# Altium schematic sheet motor_driver.SchDoc
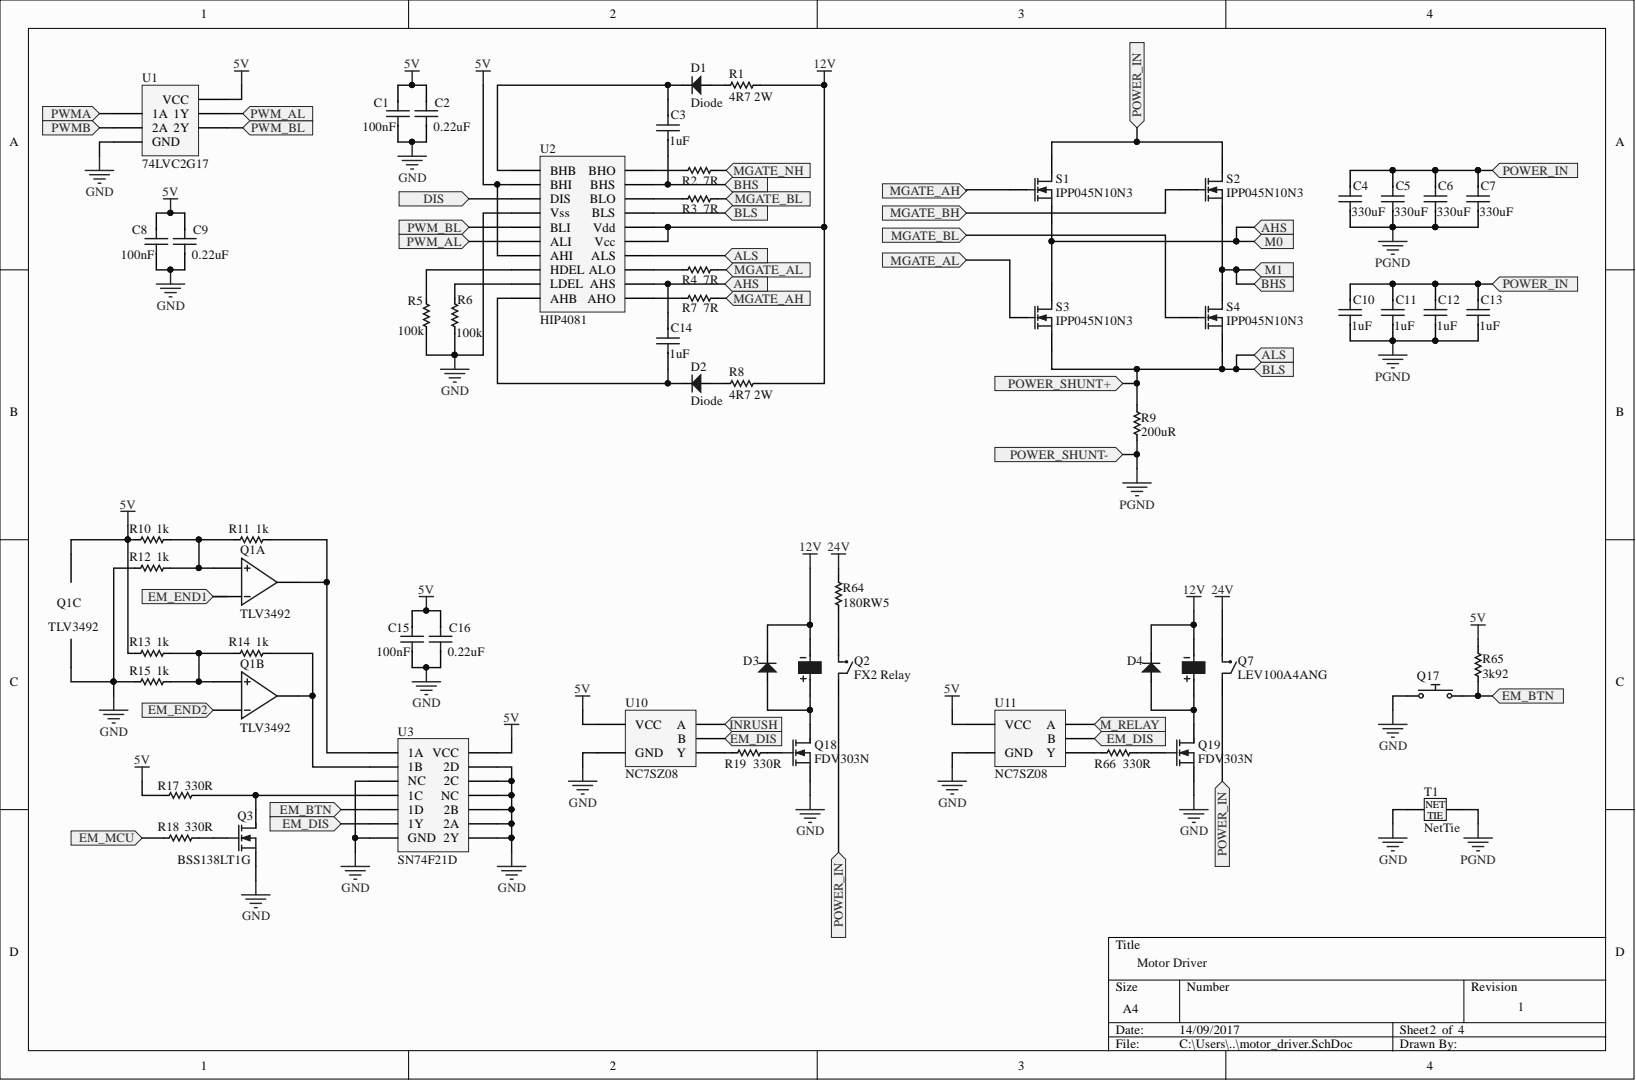
[diagram p0: motor_driver.SchDoc]

## Parts
C1  value 100nF  [0805]  (hidden comment: Capacitor)
C2  value 0.22uF  [0805]  (hidden comment: Capacitor)
C3  value 1uF  [0805]  (hidden comment: Capacitor)
C4  value 330uF  [CAP-1621]  (hidden comment: Capacitor)
C5  value 330uF  [CAP-1621]  (hidden comment: Capacitor)
C6  value 330uF  [CAP-1621]  (hidden comment: Capacitor)
C7  value 330uF  [CAP-1621]  (hidden comment: Capacitor)
C8  value 100nF  [0805]  (hidden comment: Capacitor)
C9  value 0.22uF  [0805]  (hidden comment: Capacitor)
C10  value 1uF  [0805]  (hidden comment: Capacitor)
C11  value 1uF  [0805]  (hidden comment: Capacitor)
C12  value 1uF  [0805]  (hidden comment: Capacitor)
C13  value 1uF  [0805]  (hidden comment: Capacitor)
C14  value 1uF  [0805]  (hidden comment: Capacitor)
C15  value 100nF  [0805]  (hidden comment: Capacitor)
C16  value 0.22uF  [0805]  (hidden comment: Capacitor)
D1  Diode  [DO-214AC]
D2  Diode  [DO-214AC]
D3  Diode  [DO-214AC]  (value not drawn)
D4  Diode  [DO-214AC]  (value not drawn)
Q1  TLV3492  (parts A,B,C)
Q2  FX2 Relay  [G6BK]
Q3  BSS138LT1G  [SOT-23B]
Q7  LEV100A4ANG
Q17  Emergency Button  (value not drawn)
Q18  FDV303N  [SOT-23B]
Q19  FDV303N  [SOT-23B]
R1  value 4R7 2W  [RES-2512]  (hidden comment: Resistor)
R2  value 7R  [0805]  (hidden comment: Resistor)
R3  value 7R  [0805]  (hidden comment: Resistor)
R4  value 7R  [0805]  (hidden comment: Resistor)
R5  value 100k  [0805]  (hidden comment: Resistor)
R6  value 100k  [0805]  (hidden comment: Resistor)
R7  value 7R  [0805]  (hidden comment: Resistor)
R8  value 4R7 2W  [RES-2512]  (hidden comment: Resistor)
R9  value 200uR  [RES-3920]  (hidden comment: Resistor)
R10  value 1k  [0805]  (hidden comment: Resistor)
R11  value 1k  [0805]  (hidden comment: Resistor)
R12  value 1k  [0805]  (hidden comment: Resistor)
R13  value 1k  [0805]  (hidden comment: Resistor)
R14  value 1k  [0805]  (hidden comment: Resistor)
R15  value 1k  [0805]  (hidden comment: Resistor)
R17  value 330R  [0805]  (hidden comment: Resistor)
R18  value 330R  [0805]  (hidden comment: Resistor)
R19  value 330R  [0805]  (hidden comment: Resistor)
R64  value 180RW5  [RES-RWS5]  (hidden comment: Resistor)
R65  value 3k92  (hidden comment: Resistor)
R66  value 330R  [0805]  (hidden comment: Resistor)
S1  IPP045N10N3  [TO-220AB]
S2  IPP045N10N3  [TO-220AB]
S3  IPP045N10N3  [TO-220AB]
S4  IPP045N10N3  [TO-220AB]
T1  NetTie  [NetTie]
U1  74LVC2G17  [SOT-363]
U2  HIP4081  [SOIC-20 WB]
U3  SN74F21D  [SOIC-14]
U10  NC7SZ08
U11  NC7SZ08

## Ports
AHS, ALS, BHS, BLS, DIS, EM_BTN, EM_DIS, EM_END1, EM_END2, EM_MCU, INRUSH, M0, M1, M_RELAY, MGATE_AH, MGATE_AL, MGATE_BH, MGATE_BL, MGATE_NH, POWER_IN, POWER_SHUNT+, POWER_SHUNT-, PWM_AL, PWM_BL, PWMA, PWMB

## Nets
5V: C1.2 C2.2 C8.2 C9.2 C15.2 C16.2 Q1.8 R10.1 R13.1 R17.1 R65.0 U1.5(VCC) U2.2(BHI) U2.7(AHI) U3.14(VCC) U10.5(VCC) U11.5(VCC)
12V: D3.1 D4.1 Q2.1 Q7.1 R1.0 R8.0 U2.15(Vcc) U2.16(Vdd)
24V: Q7.4 R64.0
GND: C1.1 C2.1 C8.1 C9.1 C15.1 C16.1 Q1.4 Q3.2 Q17.1 Q18.2 Q19.2 R5.0 R6.0 R12.1 R15.1 T1.2 U1.2(GND) U2.4(Vss) U3.3(NC) U3.7(GND) U3.8(2Y) U3.9(2A) U3.10(2B) U3.11(NC) U3.12(2C) U3.13(2D) U10.3(GND) U11.3(GND)
PGND: C4.1 C5.1 C6.1 C7.1 C10.1 C11.1 C12.1 C13.1 R9.1 T1.1
AHS: C14.2 S1.3 S3.2 U2.12(AHS) ; port AHS, port M0
ALS: R9.0 S3.3 S4.3 U2.14(ALS) U2.17(BLS) ; port ALS, port BLS
BHS: C3.1 S2.3 S4.2 U2.19(BHS) ; port BHS, port M1
DIS: U2.3(DIS) ; port DIS
EM_BTN: Q17.1 R65.1 U3.5(1D) ; port EM_BTN
EM_DIS: U3.6(1Y) U10.2(B) U11.2(B) ; port EM_DIS
EM_END2: Q1.6 ; port EM_END2
EM_MCU: R18.1 ; port EM_MCU
INRUSH: U10.1(A) ; port INRUSH
M_RELAY: U11.1(A) ; port M_RELAY
MGATE_AH: R7.0 S1.1 ; port MGATE_AH
MGATE_AL: R4.0 S3.1 ; port MGATE_AL
MGATE_BH: S2.1 ; port MGATE_BH
MGATE_BL: R3.0 S4.1 ; port MGATE_BL
MGATE_NH: R2.0 ; port MGATE_NH
POWER_IN: C4.2 C5.2 C6.2 C7.2 C10.2 C11.2 C12.2 C13.2 Q2.3 Q7.3 S1.2 S2.2 ; port POWER_IN
PWM_AL: U1.6(1Y) U2.6(ALI) ; port PWM_AL
PWM_BL: U1.4(2Y) U2.5(BLI) ; port PWM_BL
PWMA: U1.1(1A) ; port PWMA
PWMB: U1.3(2A) ; port PWMB
NetC3_2: C3.2 D1.1 U2.1(BHB)
NetC14_1: C14.1 D2.1 U2.10(AHB)
NetD1_2: D1.2 R1.1
NetD2_2: D2.2 R8.1
NetD3_2: D3.2 Q2.8 Q18.3
NetD4_2: D4.2 Q7.8 Q19.3
NetQ1_1: Q1.1 R11.0 U3.1(1A)
NetQ1_5: Q1.5 R13.0 R14.1 R15.0
NetQ1_7: Q1.7 R14.0 U3.2(1B)
NetQ2_4: Q2.4 R64.1
NetQ3_1: Q3.1 R18.0
NetQ3_3: Q3.3 R17.0 U3.4(1C)
NetQ18_1: Q18.1 R19.0
NetQ19_1: Q19.1 R66.0
NetR2_1: R2.1 U2.20(BHO)
NetR3_1: R3.1 U2.18(BLO)
NetR4_1: R4.1 U2.13(ALO)
NetR5_1: R5.1 U2.8(HDEL)
NetR6_1: R6.1 U2.9(LDEL)
NetR7_1: R7.1 U2.11(AHO)
NetR10_0: R10.0 R11.1 R12.0
NetR19_1: R19.1 U10.4(Y)
NetR66_1: R66.1 U11.4(Y)
N00260: ; port EM_END1

Not connected: Q1 2 3

## Library data of the parts
Q3 = BSS138LT1G: N-Channel MOSFET; Component Kind: Standard; LatestRevisionDate: 17-Jul-2002; LatestRevisionNote: Re-released for DXP Platform.; PackageDescription: TO, Flat Index; 3 In-Line, Axial Leads; Body Dia. 4.6mm; Lead Dia. 0.5 mm (max); PackageReference: E3; PackageVersion: 1995/1996; Published: 8-Jun-2000; Library Name: SDUEmbedded.SchLib; Publisher: Altium Limited
U1 = 74LVC2G17: 74LVC2G17 Dual non-inverting Schmitt-trigger with 5v tolerant input; Component Kind: Standard; Library Name: SDUEmbedded.SchLib
U3 = SN74F21D: Dual 4-Input AND Gate; Component Kind: Standard; Library Name: SDUEmbedded.SchLib
C1-C16 = Capacitor: Component Kind: Standard; Description: Capacitor; Library Name: SDUEmbedded.SchLib
D1-D4 = Diode: Component Kind: Standard; Library Name: SDUEmbedded.SchLib
Q1 = TLV3492: Component Kind: Standard; Library Name: SDUEmbedded.SchLib
Q2 = FX2 Relay: Component Kind: Standard; Library Name: SDUEmbedded.SchLib
Q7 = LEV100A4ANG: Component Kind: Standard; Library Name: SDUEmbedded.SchLib
Q17 = Emergency Button: Component Kind: Standard; Library Name: SDUEmbedded.SchLib
Q18 Q19 = FDV303N: Component Kind: Standard; Library Name: SDUEmbedded.SchLib
R1-R15 R17-R19 R64-R66 = Resistor: Component Kind: Standard; Description: Resistor; Library Name: SDUEmbedded.SchLib
S1-S4 = IPP045N10N3: Component Kind: Standard; Library Name: SDUEmbedded.SchLib
T1 = NetTie: Component Kind: Net Tie; Library Name: SDUEmbedded.SchLib
U2 = HIP4081: Component Kind: Standard; Library Name: SDUEmbedded.SchLib
U10 U11 = NC7SZ08: Component Kind: Standard; Library Name: SDUEmbedded.SchLib
